# Altium schematic sheet Jtag_port.SchDoc
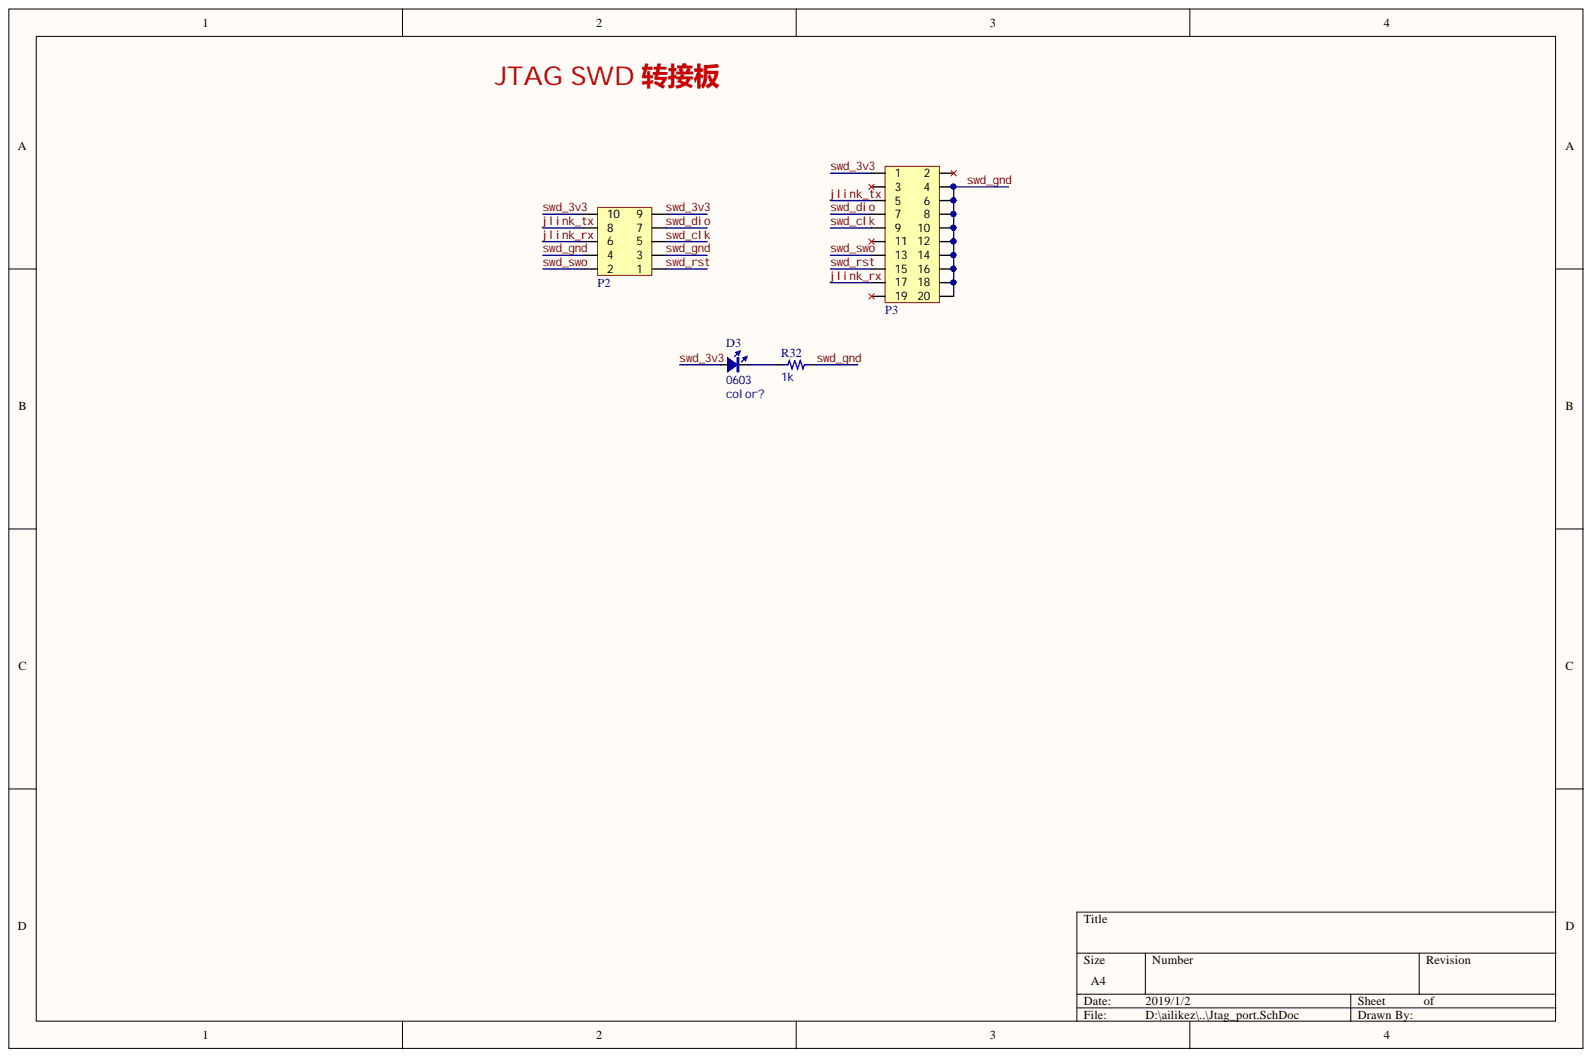
[diagram p0: Jtag_port.SchDoc]

## Parts
D3  color?  Type: 0603  [LED_0603]
P2  HDR2x5_2.54  [HDR2X5_2.54_180H]  (value not drawn)
P3  HDR2x10_2.54  [HDR2X10_2.54_180HF]  (value not drawn)
R32  1k  [RES_0603]

## Nets
jlink_rx: P2.6 P3.17
jlink_tx: P2.8 P3.5
swd_3v3: D3.1 P2.9 P2.10 P3.1
swd_clk: P2.5 P3.9
swd_dio: P2.7 P3.7
swd_gnd: P2.3 P2.4 P3.4 P3.6 P3.8 P3.10 P3.12 P3.14 P3.16 P3.18 P3.20 R32.2
swd_rst: P2.1 P3.15
swd_swo: P2.2 P3.13
NetD3_2: D3.2 R32.1

Not connected: P3 2 3 11 19

## Library data of the parts
D3 = LED_0603: LED; Component Kind: Standard; Value: color?; Library Name: adlib.IntLib
P2 = HDR2x5_2.54: Header; Component Kind: Standard; Library Name: adlib.IntLib
P3 = HDR2x10_2.54: Header; Component Kind: Standard; Library Name: adlib.IntLib
R32 = Res: SMT Resistor; Component Kind: Standard; Value: 1k; Library Name: adlib.IntLib
